# Altium schematic sheet Sheet4.SchDoc(Sheet4)
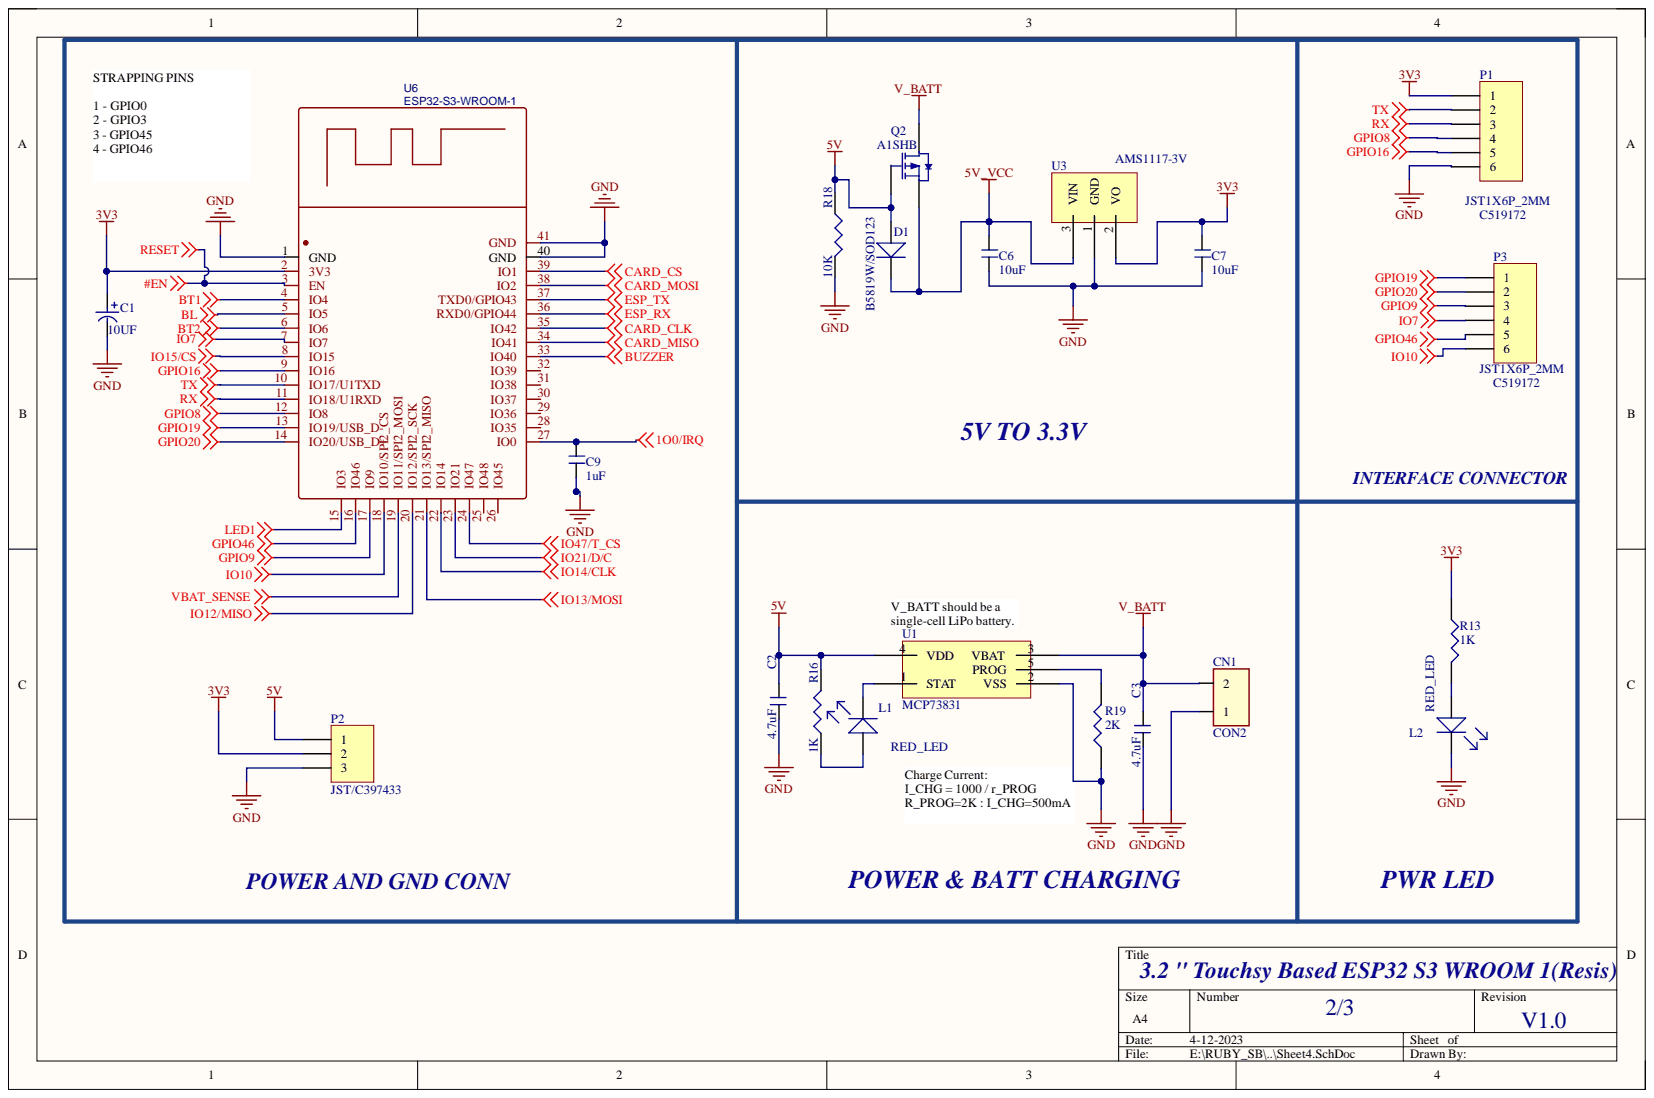
[diagram p0: Sheet4.SchDoc]

## Parts
C1  10UF  [tantlum caseA]
C2  4.7uF  [C_0603]
C3  4.7uF  [C_0603]
C6  10uF  [C_0603]
C7  10uF  [C_0603]
C9  1uF  [C_0603]
CN1  CON2  [JST2P_P2.0mm]
D1  B5819W/SOD123  [SOD-123]
L1  RED_LED  [LED_0603]
L2  RED_LED  [LED_0603]
P1  JST1X6P_2MM  [JST_6P_2MM]
P2  JST/C397433  [3pin jst 2.5mm]
P3  JST1X6P_2MM  [JST_6P_2MM]
Q2  A1SHB  [BSS84]
R13  1K  [R_0603]
R16  1K  [R_0603]
R18  10K  [R_0603]
R19  2K  [R_0603]
U1  MCP73831  [SOT23-5]
U3  AMS1117-3V  [AMS1117_3V3]
U6  ESP32-S3-WROOM-1  [ESP32-S3 WROOM 1]

## Nets
1O0/IRQ: C9.2 U6.27(IO0)
3V3: C1.1 C7.2 P1.1 P2.2 R13.1 U3.2 U6.2(3V3)
5V: C2.1 D1.A P2.1 Q2.1 R16.2 R18.1 U1.4(VDD)
5V_VCC: C6.2 D1.K Q2.2 U3.3
#EN: U6.3(EN)
BL: U6.5(IO5)
BT1: U6.4(IO4)
BT2: U6.6(IO6)
BUZZER: U6.33(IO40)
CARD_CLK: U6.35(IO42)
CARD_CS: U6.39(IO1)
CARD_MISO: U6.34(IO41)
CARD_MOSI: U6.38(IO2)
ESP_RX: U6.36(RXD0/GPIO44)
ESP_TX: U6.37(TXD0/GPIO43)
GND: C1.2 C2.2 C3.2 C6.1 C7.1 C9.1 CN1.1 L2.K P1.6 P2.3 R18.2 R19.1 U1.2(VSS) U3.1 U6.1(GND) U6.40(GND) U6.41(GND)
GPIO8: P1.4 U6.12(IO8)
GPIO9: P3.3 U6.17(IO9)
GPIO16: P1.5 U6.9(IO16)
GPIO19: P3.1 U6.13(IO19/USB_D-)
GPIO20: P3.2 U6.14(IO20/USB_D+)
GPIO46: P3.5 U6.16(IO46)
IO7: P3.4 U6.7(IO7)
IO10: P3.6 U6.18(IO10/SPI2_CS)
IO12/MISO: U6.20(IO12/SPI2_SCK)
IO13/MOSI: U6.21(IO13/SPI2_MISO)
IO14/CLK: U6.22(IO14)
IO15/CS: U6.8(IO15)
IO21/D/C: U6.23(IO21)
IO47/T_CS: U6.24(IO47)
LED1: U6.15(IO3)
RX: P1.3 U6.11(IO18/U1RXD)
TX: P1.2 U6.10(IO17/U1TXD)
V_BATT: C3.1 CN1.2 Q2.3 U1.3(VBAT)
VBAT_SENSE: U6.19(IO11/SPI2_MOSI)
NetL1_A: L1.A R16.1
NetL1_K: L1.K U1.1(STAT)
NetL2_A: L2.A R13.2
NetR19_2: R19.2 U1.5(PROG)

Not connected: U6 25(IO48) 26(IO45) 28(IO35) 29(IO36) 30(IO37) 31(IO38) 32(IO39)

## Library data of the parts
C1 = CAP_ELE: Component Kind: Standard; Library Name: MIX.SchLib
C2 C3 C6 C7 C9 = CAP_NONPOLAR: Component Kind: Standard; Library Name: dxp_pasl_lib.IntLib
D1 = DIODE: Component Kind: Standard; Library Name: dxp_pasl_lib.IntLib
L1 L2 = LED: Component Kind: Standard; Library Name: dxp_pasl_lib.IntLib
P1 P3 = Header 6: Header, 6-Pin; Component Kind: Standard; LatestRevisionDate: 17-Jul-2002; LatestRevisionNote: Re-released for DXP Platform.; Library Name: Miscellaneous Connectors.IntLib; Signal Integrity: Connector; Publisher: Altium Limited
P2 = Header 3: Header, 3-Pin; Component Kind: Standard; LatestRevisionDate: 17-Jul-2002; LatestRevisionNote: Re-released for DXP Platform.; Library Name: Miscellaneous Connectors.IntLib; Signal Integrity: Connector; Publisher: Altium Limited
Q2 = BSS84: 50V 130mA 250mW 10Ω 10V,130mA 2V 1mA P Channel SOT-23(SOT-23-3) MOSFETs ROHS #C493579; PackageDocument: 1995/1996; CATEGORY: MOSFET; Component Kind: Standard; LatestRevisionDate: 17-Jul-2002; LatestRevisionNote: Re-released for DXP Platform.; PackageReference: E3; Published: 8-Jun-2000; Library Name: dxp_pasl_lib.IntLib; Publisher: Altium Limited
R13 R16 R18 R19 = RES: Component Kind: Standard; Library Name: dxp_pasl_lib.IntLib
U3 = AMS1117: Component Kind: Standard; Library Name: dxp_pasl_lib.IntLib
U6 = ESP32-S3-WROOM-1(N16): BOM_Manufacturer: ESPRESSIF(乐鑫); BOM_Manufacturer Part: ESP32-S3-WROOM-1-N16; Contributor: lcsc; BOM_JLCPCB Part Class: Extended Part; BOM_Supplier: LCSC; BOM_Supplier Part: C2913199; Component Kind: Standard; link: https://atta.szlcsc.com/upload/public/pdf/source/20220628/A2AC12B3C87735CAEB7EBE2DBE80936C.pdf; spicePre: U; spiceSymbolName: ESP32-S3-WROOM-1(N16)
CN1 = CON2: Component Kind: Standard; Library Name: dxp_pasl_lib.IntLib
U1 = MCP73831: Component Kind: Standard; Library Name: dxp_pasl_lib.IntLib
